# Altium schematic sheet GSM_Module.SchDoc
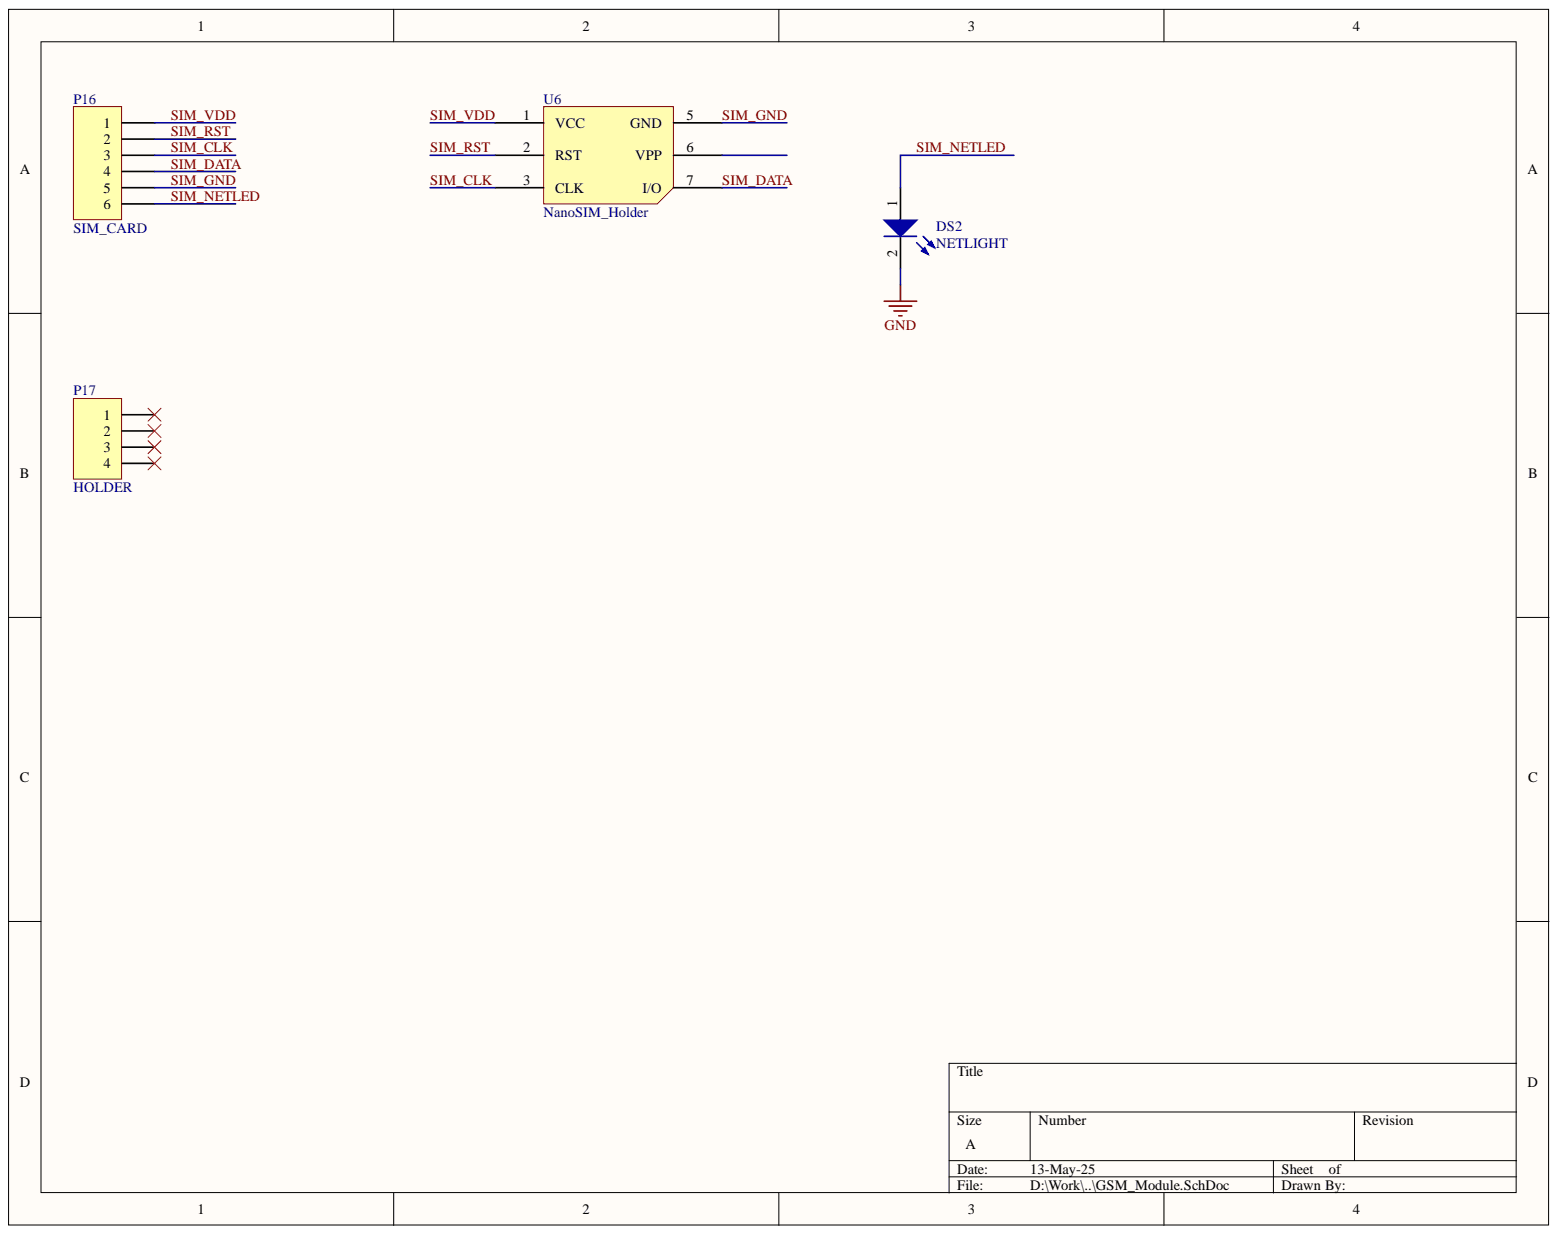
[diagram p0: GSM_Module.SchDoc]

## Parts
DS2  NETLIGHT  [0805_LED]
P16  SIM_CARD  [HDR1X6]
P17  HOLDER  [HDR1X4]
U6  NanoSIM_Holder  [Nano_SIMCard_Holder]

## Nets
GND: DS2.2
SIM_CLK: P16.3 U6.3(CLK)
SIM_DATA: P16.4 U6.7(I/O)
SIM_GND: P16.5 U6.5(GND)
SIM_NETLED: DS2.1 P16.6
SIM_RST: P16.2 U6.2(RST)
SIM_VDD: P16.1 U6.1(VCC)

Not connected: P17 1 2 3 4; U6 6(VPP)

## Library data of the parts
DS2 = LED0: LED 5mm Diameter; Component Kind: Standard; LatestRevisionDate: 08-Jul-2005; LatestRevisionNote: Mech Layer 1 removed.; Library Name: Miscellaneous Devices.IntLib; PackageDescription: LED; 2 Leads; PackageReference: LED-0; Published: 8-Jun-2000; Simulation: LED0; Publisher: Altium Limited
P16 = Header 6: Header, 6-Pin; Component Kind: Standard; LatestRevisionDate: 17-Jul-2002; LatestRevisionNote: Re-released for DXP Platform.; Library Name: Miscellaneous Connectors.IntLib; Signal Integrity: Connector; Publisher: Altium Limited
P17 = Header 4: Header, 4-Pin; Component Kind: Standard; LatestRevisionDate: 17-Jul-2002; LatestRevisionNote: Re-released for DXP Platform.; Library Name: Miscellaneous Connectors.IntLib; Signal Integrity: Connector; Publisher: Altium Limited
U6 = NanoSIM_Holder: Component Kind: Standard; Library Name: SchLib.SchLib
